# Altium schematic sheet Power Supply 2.SchDoc("Power Supply 2")
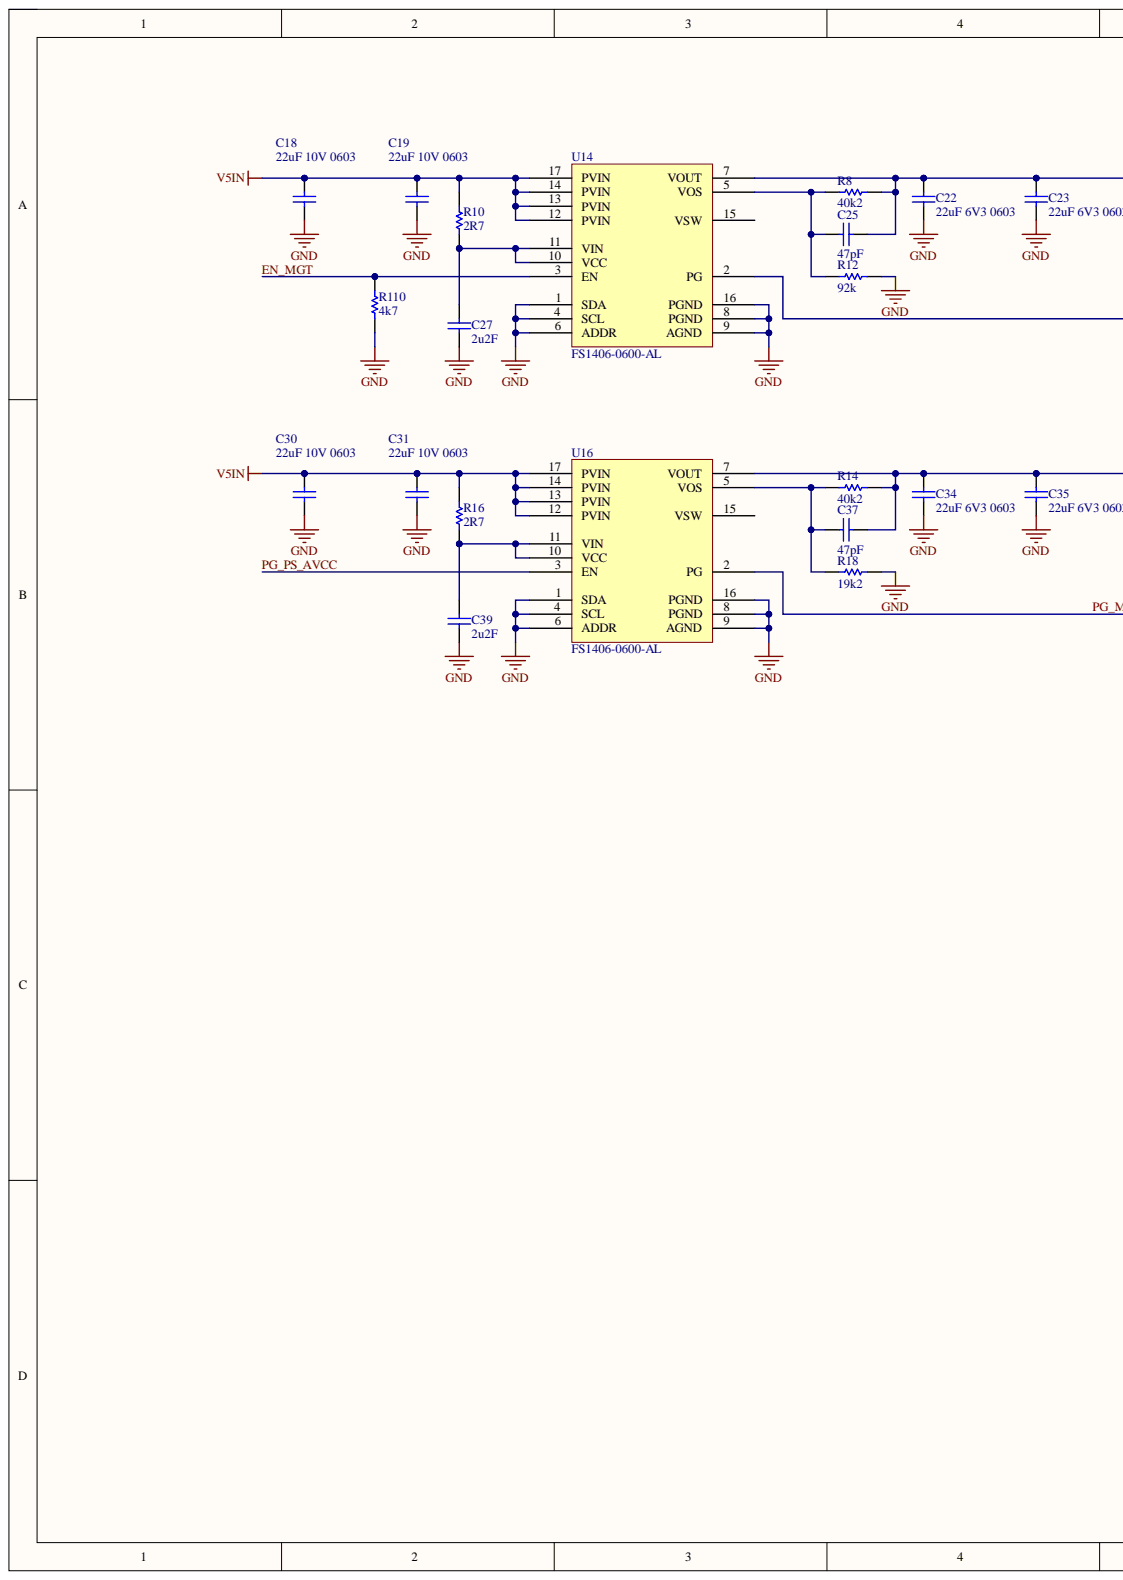
[diagram p0: Power Supply 2.SchDoc, left]
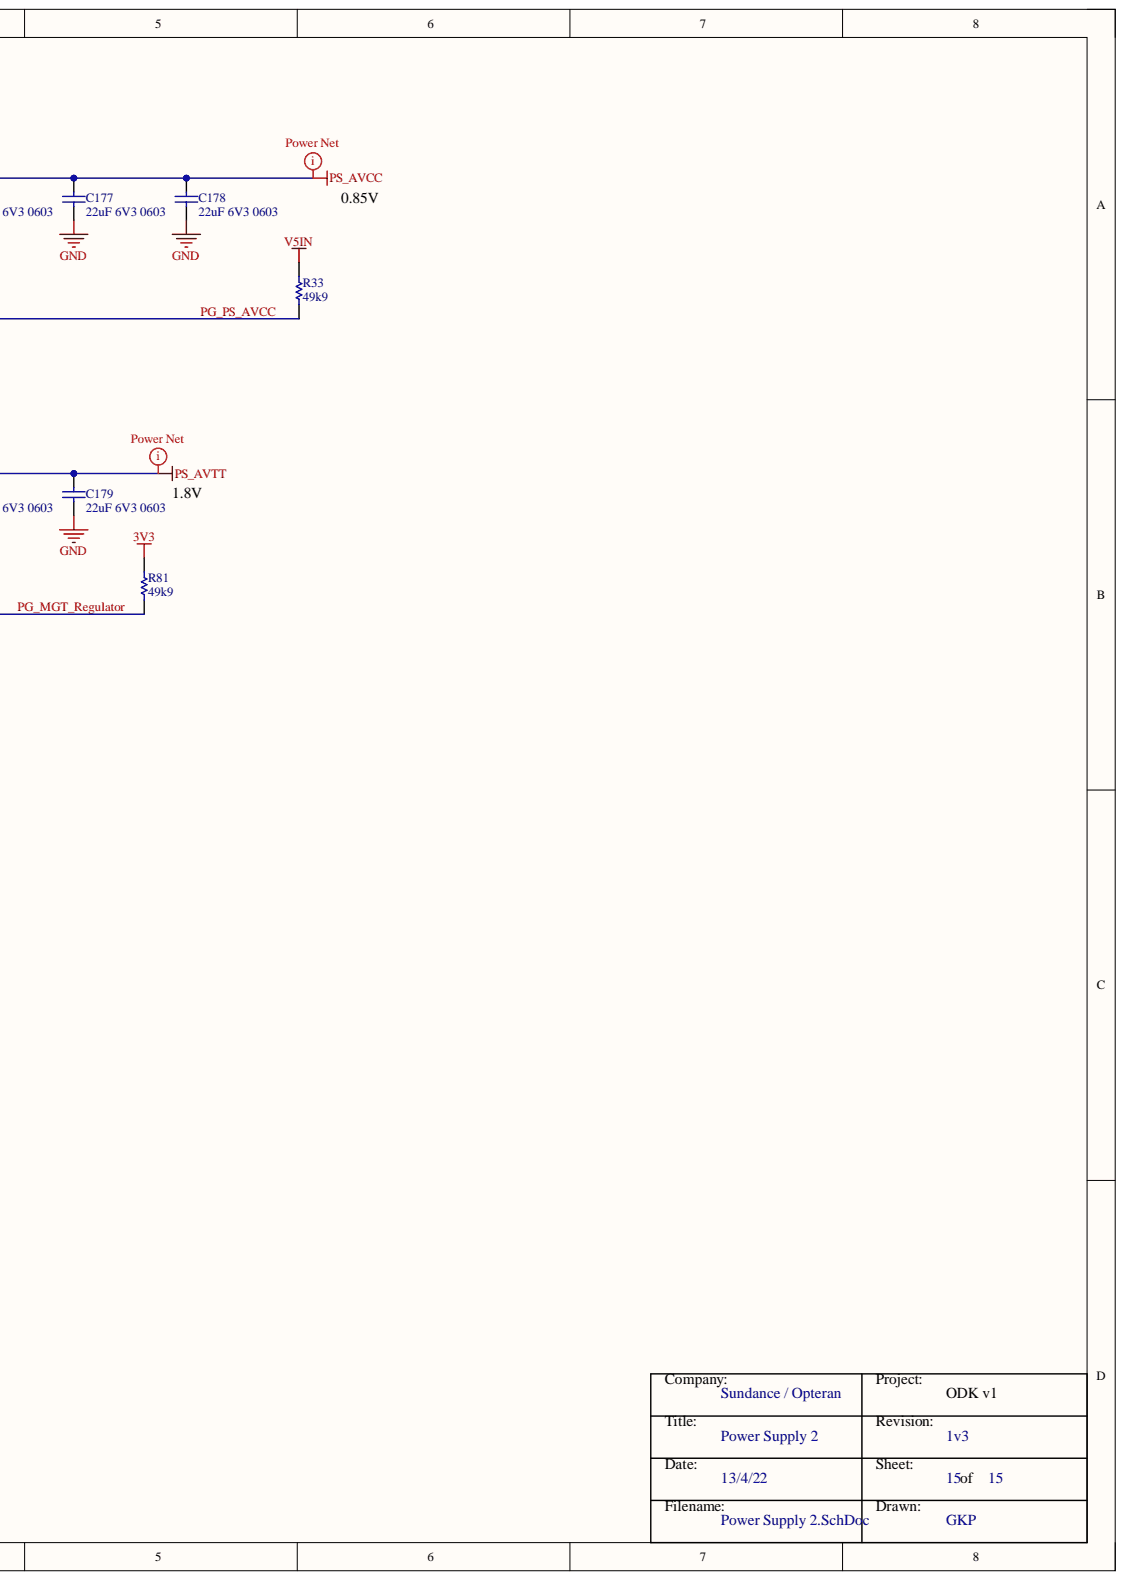
[diagram p1: Power Supply 2.SchDoc, right]

## Parts
C18  22uF 10V 0603  Case-EIA: 0603  [CAP0603]
C19  22uF 10V 0603  Case-EIA: 0603  [CAP0603]
C22  22uF 6V3 0603  [CAP0603]
C23  22uF 6V3 0603  Case-EIA: 0603  [CAP0603]
C25  47pF  [0201panERJ]
C27  2u2F  [0201panERJ]
C30  22uF 10V 0603  Case-EIA: 0603  [CAP0603]
C31  22uF 10V 0603  Case-EIA: 0603  [CAP0603]
C34  22uF 6V3 0603  [CAP0603]
C35  22uF 6V3 0603  Case-EIA: 0603  [CAP0603]
C37  47pF  [0201panERJ]
C39  2u2F  [0201panERJ]
C177  22uF 6V3 0603  Case-EIA: 0603  [CAP0603]
C178  22uF 6V3 0603  Case-EIA: 0603  [CAP0603]
C179  22uF 6V3 0603  Case-EIA: 0603  [CAP0603]
R8  40k2  [RES0201]
R10  2R7  [RES0201]
R12  92k  [RES0201]
R14  40k2  [RES0201]
R16  2R7  [RES0201]
R18  19k2  [RES0201]
R33  49k9  [RES0201]
R81  49k9  [RES0201]
R110  4k7  [RES0201]
U14  FS1406-0600-AL  [FS1406_TDK]
U16  FS1406-0600-AL  [FS1406_TDK]

## Nets
3V3: R81.2
GND: C18.1 C19.1 C22.1 C23.1 C27.1 C30.1 C31.1 C34.1 C35.1 C39.1 C177.1 C178.1 C179.1 R12.1 R18.1 R110.1 U14.1(SDA) U14.4(SCL) U14.6(ADDR) U14.8(PGND) U14.9(AGND) U14.16(PGND) U16.1(SDA) U16.4(SCL) U16.6(ADDR) U16.8(PGND) U16.9(AGND) U16.16(PGND)
PS_AVCC: C22.2 C23.2 C25.1 C177.2 C178.2 R8.1 U14.7(VOUT)
PS_AVTT: C34.2 C35.2 C37.1 C179.2 R14.1 U16.7(VOUT)
V5IN: C18.2 C19.2 C30.2 C31.2 R10.2 R16.2 R33.2 U14.12(PVIN) U14.13(PVIN) U14.14(PVIN) U14.17(PVIN) U16.12(PVIN) U16.13(PVIN) U16.14(PVIN) U16.17(PVIN)
EN_MGT: R110.2 U14.3(EN)
PG_MGT_Regulator: R81.1 U16.2(PG)
PG_PS_AVCC: R33.1 U14.2(PG) U16.3(EN)
NetC25_2: C25.2 R8.2 R12.2 U14.5(VOS)
NetC27_2: C27.2 R10.1 U14.10(VCC) U14.11(VIN)
NetC37_2: C37.2 R14.2 R18.2 U16.5(VOS)
NetC39_2: C39.2 R16.1 U16.10(VCC) U16.11(VIN)

Not connected: U14 15(VSW); U16 15(VSW)

## Library data of the parts
C18 C19 C30 C31 = Cap0603: 22uF 10V 0603 X5R; Component Kind: Standard; Library Name: Schematic Components.SchLib; Max Thickness: 0.6 mm; Technology: SMT
C22 C34 = Cap0603: 22uF 6V3 0603 X5R; Case-EIA: 0603; Component Kind: Standard; Library Name: Schematic Components.SchLib; Max Thickness: 0.6 mm; Technology: SMT
C23 C35 C177-C179 = Cap0603: 22uF 6V3 0603 X5R; Component Kind: Standard; Library Name: Schematic Components.SchLib; Max Thickness: 0.6 mm; Technology: SMT
C25 C37 = Cap0201: 47pF 0201 6V3 X5R; Case-EIA: 0402; Component Kind: Standard; Library Name: Schematic Components.SchLib; Max Thickness: 0.6 mm; Technology: SMT
C27 C39 = Cap0201: 2u2F 0201 10V X5R; Case-EIA: 0402; Component Kind: Standard; Library Name: Schematic Components.SchLib; Max Thickness: 0.6 mm; Technology: SMT
R8 R14 = Res0201: 40k2 0201 1/16W 1%; Value: ?k; Component Kind: Standard; Library Name: Schematic Components.SchLib
R10 R16 = Res0201: 2R7 0201 1/16W 1%; Value: ?k; Component Kind: Standard; Library Name: Schematic Components.SchLib
R12 = Res0201: 92k 0201 1/16W 1%; Value: ?k; Component Kind: Standard; Library Name: Schematic Components.SchLib
R18 = Res0201: 19k2 0201 1/16W 1%; Value: ?k; Component Kind: Standard; Library Name: Schematic Components.SchLib
R33 R81 = Res0201: 49k9 0201 1/16W 1%; Value: ?k; Component Kind: Standard; Library Name: Schematic Components.SchLib
R110 = Res0201: 4k7 0201 1/16W 1%; Value: ?k; Component Kind: Standard; Library Name: Schematic Components.SchLib
U14 U16 = TDK FS1406: Non-Isolated PoL Module, Digital DC DC Converter 1 Output 0.6V - - - 6A 4.5V - 16V Input; Manufacturer 1: TDK Corporation; Component Kind: Standard; Library Name: Schematic Components.SchLib; Manufacturer Part Number 1: FS1406-0600-AL; Supplier 1: Digi-Key; Supplier Part Number 1: 445-FS1406-0600-ALTR-ND
